# Altium schematic sheet Ax2WDRobot.SchDoc
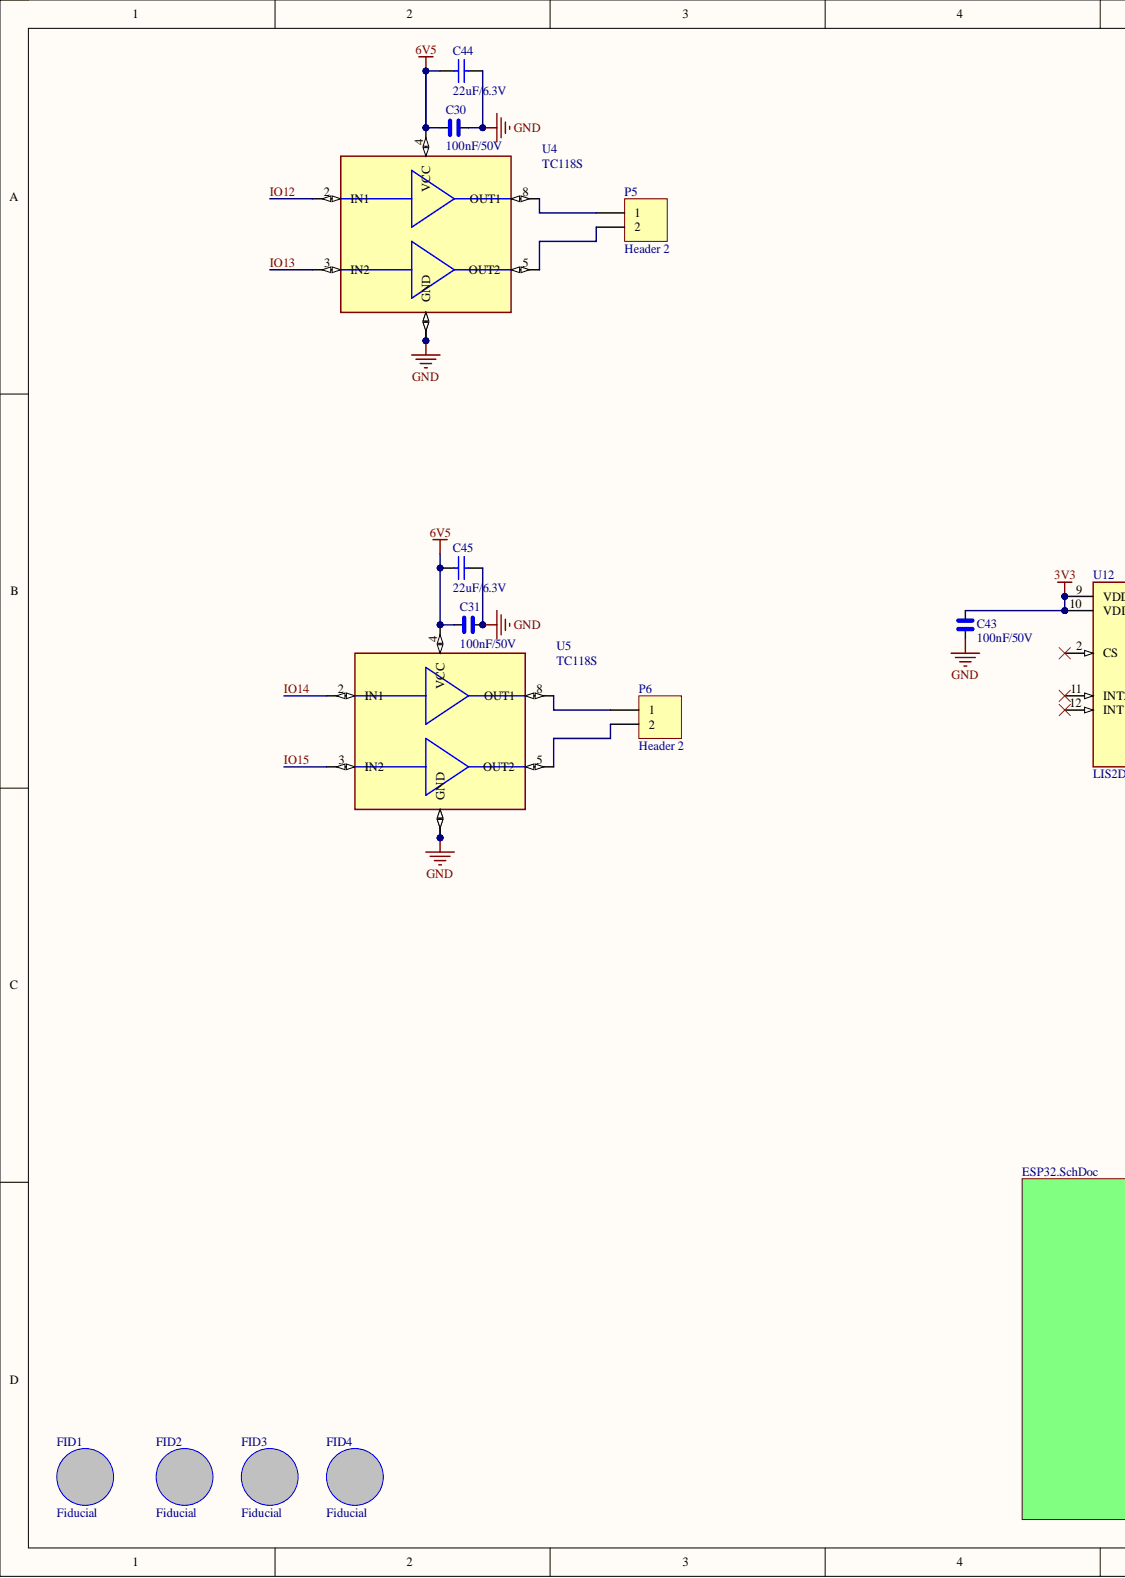
[diagram p0: Ax2WDRobot.SchDoc, left]
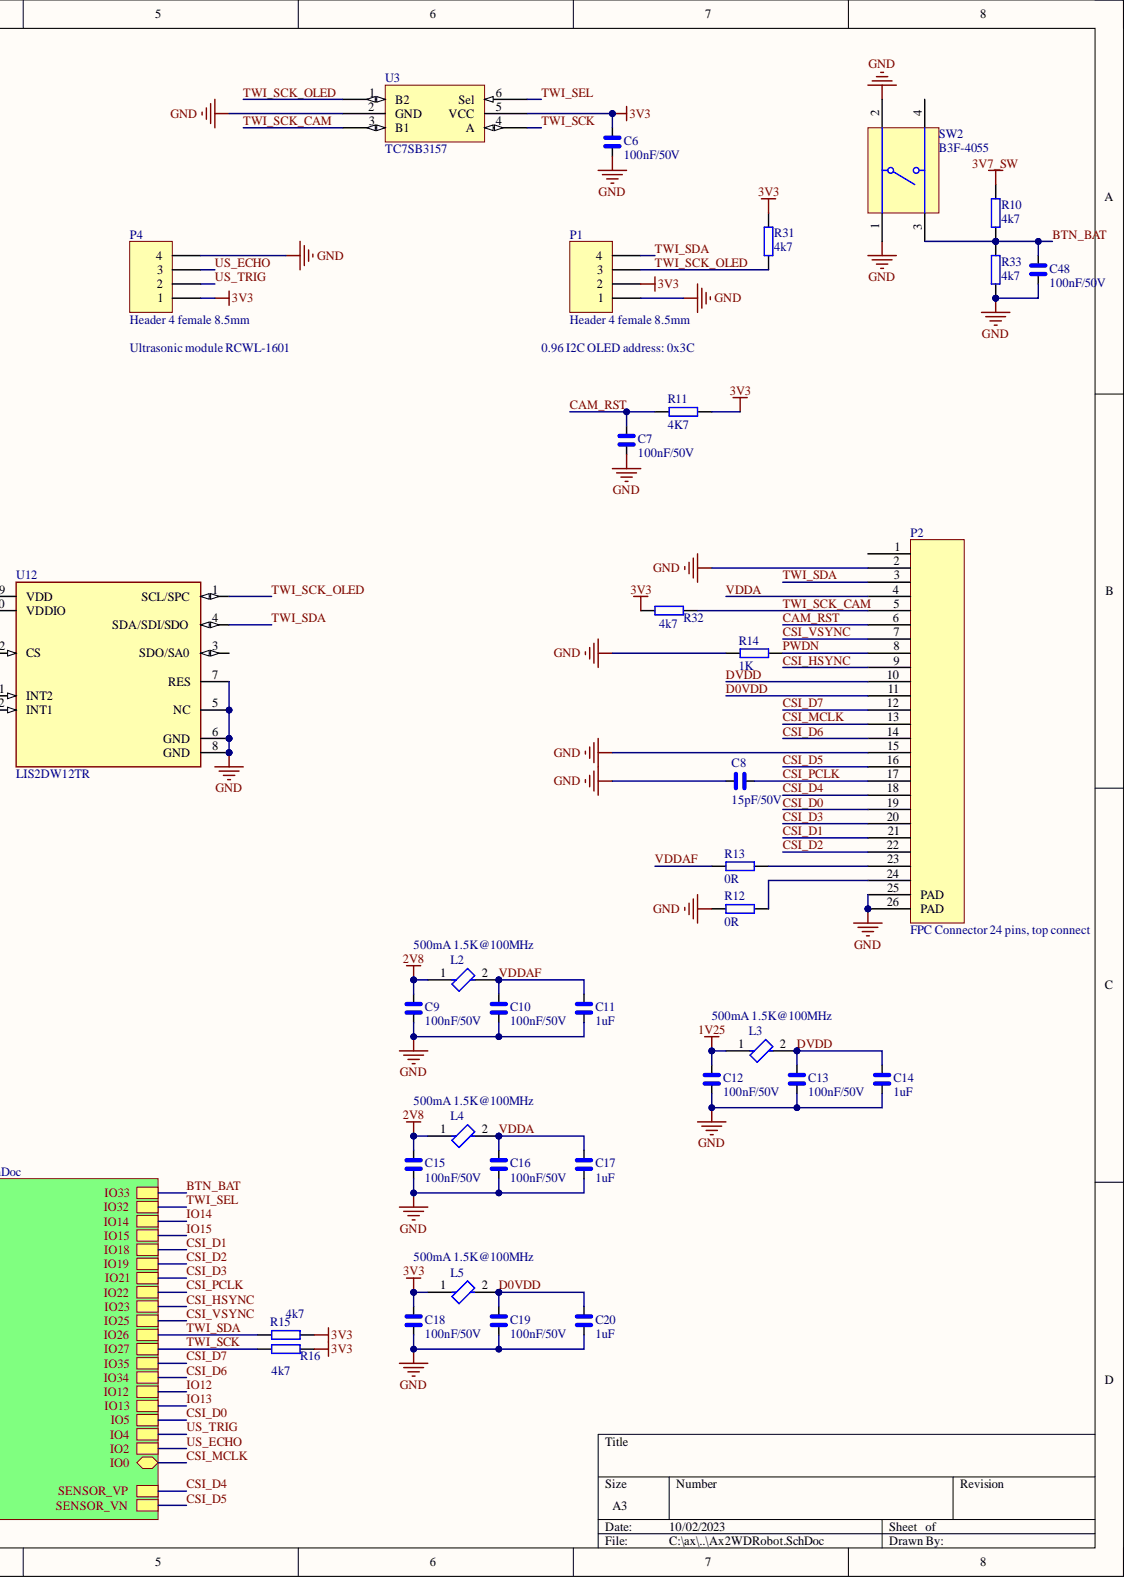
[diagram p1: Ax2WDRobot.SchDoc, right]

## Parts
C6  100nF/50V  [1608[0603]]
C7  100nF/50V  [1608[0603]]
C8  15pF/50V  [1608[0603]]
C9  100nF/50V  [1608[0603]]
C10  100nF/50V  [1608[0603]]
C11  1uF  [1608[0603]]
C12  100nF/50V  [1608[0603]]
C13  100nF/50V  [1608[0603]]
C14  1uF  [1608[0603]]
C15  100nF/50V  [1608[0603]]
C16  100nF/50V  [1608[0603]]
C17  1uF  [1608[0603]]
C18  100nF/50V  [1608[0603]]
C19  100nF/50V  [1608[0603]]
C20  1uF  [1608[0603]]
C30  100nF/50V  [1608[0603]]
C31  100nF/50V  [1608[0603]]
C43  100nF/50V  [1608[0603]]
C44  22uF/6.3V  [1608[0603]]
C45  22uF/6.3V  [1608[0603]]
C48  100nF/50V  [1608[0603]]
FID1  Fiducial  [FIDUCIAL_POLY]  (no BOM)
FID2  Fiducial  [FIDUCIAL_POLY]  (no BOM)
FID3  Fiducial  [FIDUCIAL_POLY]  (no BOM)
FID4  Fiducial  [FIDUCIAL_POLY]  (no BOM)
L2  500mA 1.5K@100MHz  [1608[0603]]
L3  500mA 1.5K@100MHz  [1608[0603]]
L4  500mA 1.5K@100MHz  [1608[0603]]
L5  500mA 1.5K@100MHz  [1608[0603]]
P1  Header 4 female 8.5mm  [BCS-104-L-S-TE]
P2  FPC Connector 24 pins, top connect  [AFC07-S24ECA-00]
P4  Header 4 female 8.5mm  [BCS-104-L-S-TE]
P5  Header 2  [BCS-102-L-S-TE]
P6  Header 2  [BCS-102-L-S-TE]
R10  4k7  [1608[0603]]
R11  4K7  [1608[0603]]
R12  0R  [1608[0603]]  (no BOM)
R13  0R  [1608[0603]]  (no BOM)
R14  1K  [1608[0603]]
R15  4k7  [1608[0603]]
R16  4k7  [1608[0603]]
R31  4k7  [1608[0603]]
R32  4k7  [1608[0603]]
R33  4k7  [1608[0603]]
SW2  B3F-4055  [OMRON-B3F-4055_AX]
U3  TC7SB3157  [SOT-363_MP6D]
U4  TC118S  [SO08]
U5  TC118S  [SO08]
U12  LIS2DW12TR  [FP-LGA12-DM00170568-MFG]

## Nets
1V25: C12.2 L3.1
2V8: C9.2 C15.2 L2.1 L4.1
3V3: C6.1 C18.2 C43.1 L5.1 P1.2 P4.1 R11.2 R15.1 R16.1 R31.2 R32.2 U3.5(VCC) U12.9(VDD) U12.10(VDDIO)
3V7_SW: R10.2
6V5: C30.2 C31.2 C44.2 C45.2 U4.4(VCC) U5.4(VCC)
GND: C6.2 C7.1 C8.1 C9.1 C10.1 C11.2 C12.1 C13.1 C14.2 C15.1 C16.1 C17.2 C18.1 C19.1 C20.2 C30.1 C31.1 C43.2 C44.1 C45.1 C48.1 P1.1 P2.2 P2.15 P2.25(PAD) P2.26(PAD) P4.4 R12.1 R14.1 R33.1 SW2.1 SW2.2 U3.2(GND) U4.6(GND) U4.7 U5.6(GND) U5.7 U12.5(NC) U12.6(GND) U12.7(RES) U12.8(GND)
BTN_BAT: C48.2 R10.1 R33.2 SW2.3
CAM_RST: C7.2 P2.6 R11.1
CSI_D0: P2.19
CSI_D1: P2.21
CSI_D2: P2.22
CSI_D3: P2.20
CSI_D4: P2.18
CSI_D5: P2.16
CSI_D6: P2.14
CSI_D7: P2.12
CSI_HSYNC: P2.9
CSI_MCLK: P2.13
CSI_PCLK: C8.2 P2.17
CSI_VSYNC: P2.7
D0VDD: C19.2 C20.1 L5.2 P2.11
DVDD: C13.2 C14.1 L3.2 P2.10
IO12: U4.2(IN1)
IO13: U4.3(IN2)
IO14: U5.2(IN1)
IO15: U5.3(IN2)
PWDN: P2.8 R14.2
TWI_SCK: R16.2 U3.4(A)
TWI_SCK_CAM: P2.5 R32.1 U3.3(B1)
TWI_SCK_OLED: P1.3 R31.1 U3.1(B2) U12.1(SCL/SPC)
TWI_SDA: P1.4 P2.3 R15.2 U12.4(SDA/SDI/SDO)
TWI_SEL: U3.6(Sel)
US_ECHO: P4.3
US_TRIG: P4.2
VDDA: C16.2 C17.1 L4.2 P2.4
VDDAF: C10.2 C11.1 L2.2 R13.1
NetP2_1: P2.1
NetP2_23: P2.23 R13.2
NetP2_24: P2.24 R12.2
NetP5_1: P5.1 U4.8(OUT1)
NetP5_2: P5.2 U4.5(OUT2)
NetP6_1: P6.1 U5.8(OUT1)
NetP6_2: P6.2 U5.5(OUT2)
NetSW2_4: SW2.4
NetU12_2: U12.2(CS)
NetU12_3: U12.3(SDO/SA0)
NetU12_11: U12.11(INT2)
NetU12_12: U12.12(INT1)

## Library data of the parts
C6 C7 C9 C10 C12 C13 C15 C16 C18 C19 C30 C31 C48 = C: Cap Ceramic 0.1uF 50V X7R 20% SMD 0603 125C Paper T/R; Case/Package: 0603; Component Kind: Standard; LCSCPartNo: C14663; Library Name: Sch.lib; Supplier 1: Digi-Key; Supplier Part Number 1: 1276-1941-1-ND
C8 = C: Cap Ceramic 0603; Case/Package: 0603; Component Kind: Standard; LCSCPartNo: C1644; Library Name: Sch.lib; Supplier 1: Digi-Key
C11 C14 C17 C20 = C: CAP CER 1UF 10V X5R 0603; Case/Package: 0603; Component Kind: Standard; LCSCPartNo: C15849; Library Name: Sch.lib; Supplier 1: Digi-Key; Supplier Part Number 1: 1276-1866-1-ND
C43 = C: Cap Ceramic 0.1uF 50V X7R 20% SMD 0603 125C Paper T/R; Manufacturer: Samsung; Manufacturer Part Number: CL10B104MB8NNNC; Case/Package: 0603; Component Kind: Standard; LCSCPartNo: C14663; Library Name: Sch.lib; Supplier 1: Digi-Key; Supplier Part Number 1: 1276-1941-1-ND
C44 C45 = Cap: Capacitor; Case/Package: 0603; Component Kind: Standard; LCSCPartNo: C59461; Library Name: Miscellaneous Devices.IntLib; Signal Integrity: Cap; Simulation: CAP
L2-L5 = CMP-0686-00368-3: Chip Ferrite Bead, 0603, 1500Ω @ 100MHz, 0.5Ω, 25%, 500mA; Case/Package: 0603; Component Kind: Standard; LCSCPartNo: C82155; Library Name: Altium Content Vault; Supplier 1: Digi-Key; Supplier Part Number 1: 490-5216-1-ND
P1 P4 = Header 4: Header, 4-Pin; Component Kind: Standard; LCSCPartNo: C124413; Library Name: Miscellaneous Connectors.IntLib; Signal Integrity: Connector; Supplier 1: Digi-Key
P2 = FPC-CONN-24: Component Kind: Standard; LCSCPartNo: C262643; Library Name: Cam1.SCHLIB
P5 P6 = Header 2: Header, 2-Pin; Component Kind: Standard; LatestRevisionDate: 17-Jul-2002; LatestRevisionNote: Re-released for DXP Platform.; LCSCPartNo: C49661; Library Name: Miscellaneous Connectors.IntLib; Signal Integrity: Connector; Publisher: Altium Limited
R10 R15 R16 R31-R33 = R: RES SMD 4.7K OHM 1% 1/10W 0603; Case/Package: 0603; Component Kind: Standard; LCSCPartNo: C23162; Library Name: Sch.lib; Supplier 1: Digi-Key; Supplier Part Number 1: 311-4.70KHRCT-ND
R11-R14 = R: Res General Purpose Thick Film 0603 1% 1/10W ±100ppm/°C Molded SMD Paper T/R; Case/Package: 0603; Library Name: Sch.lib; Supplier 1: Digi-Key
  R11: Component Kind: Standard; LCSCPartNo: C23162
  R12 R13: LCSCPartNo: C21189
  R14: Component Kind: Standard; LCSCPartNo: C21190
SW2 = CMP-2000-05902-1: Tactile Switch, 1 to 50 mA, 100 mOhm Max, 5 to 24 VDC, -25 to 70 degC, 4-Pin THD, RoHS, Bulk; Component Kind: Standard; LCSCPartNo: C84931; Library Name: Omron_B3F-4055.SchLib
U3 = TC7SB3157CFU: 1-of-2 multiplexer/demultiplexer; Component Kind: Standard; LCSCAltPartNo: C150170; LCSCPartNo: C2674458; Library Name: CombinedMultiplexerMainboard.SchLib
U12 = CMP-12175-000006-1: ACCEL 2-16G I2C/SPI 12LGA; Manufacturer: STMicroelectronics; Accel Range: 2/4/8/16g; Accel Resolution: 14bits; Max Supply Voltage: 3.6V; Min Supply Voltage: 1.62V; Operating Supply Current: 0.38-90uA; Sensor Output: I2C,SPI; Automotive: No; Category: IC; Component Kind: Standard; ComponentLink2Description: Datasheet; ComponentLink2URL: https://www.st.com/resource/en/datasheet/lis2dw12.pdf; Device Class L1: Sensors; Device Class L2: Motion Sensors; Device Class L3: Accelerometers; Digikey Description: ACCEL 2-16G I2C/SPI 12LGA; Footprint Url: https://www.st.com/content/ccc/resource/technical/document/technical_note/73/17/9c/16/dd/96/4a/01/CD00134799.pdf/files/CD00134799.pdf/jcr:content/translations/en.CD00134799.pdf; Height: 0.7mm; LCSCPartNo: C189624; Lead Free: Yes; Library Name: Altium Content Vault; Manufacturer Part Number: LIS2DW12TR; Mouser Description: Accelerometer Triple ±2g/±4g/±8g/±16g 1.62V to 3.6V 0.244mg/digit/0.488mg/digit/0.976mg/digit/1.952mg/digit 12-Pin LG...; Octopart: https://octopart.com/lis2dw12tr-stmicroelectronics-77080925; Package: LGA12-DM00170568; RoHS: TRUE; Temperature: -40°C to +85°C; Temperature Range High: +85°C; Temperature Range Low: -40°C
FID1-FID4 = Fiducial: Description: Fiducial; Library Name: RJ45_Jack.SchLib
U4 U5 = TC118S: Component Kind: Standard; LCSCPartNo: C88308; Library Name: TC118S.SchLib
